# Altium schematic sheet 1400-10010-SCH-Legend-02-Protection V4.3.SchDoc("1400-10010-SCH-Legend-02-Protection V4.3")
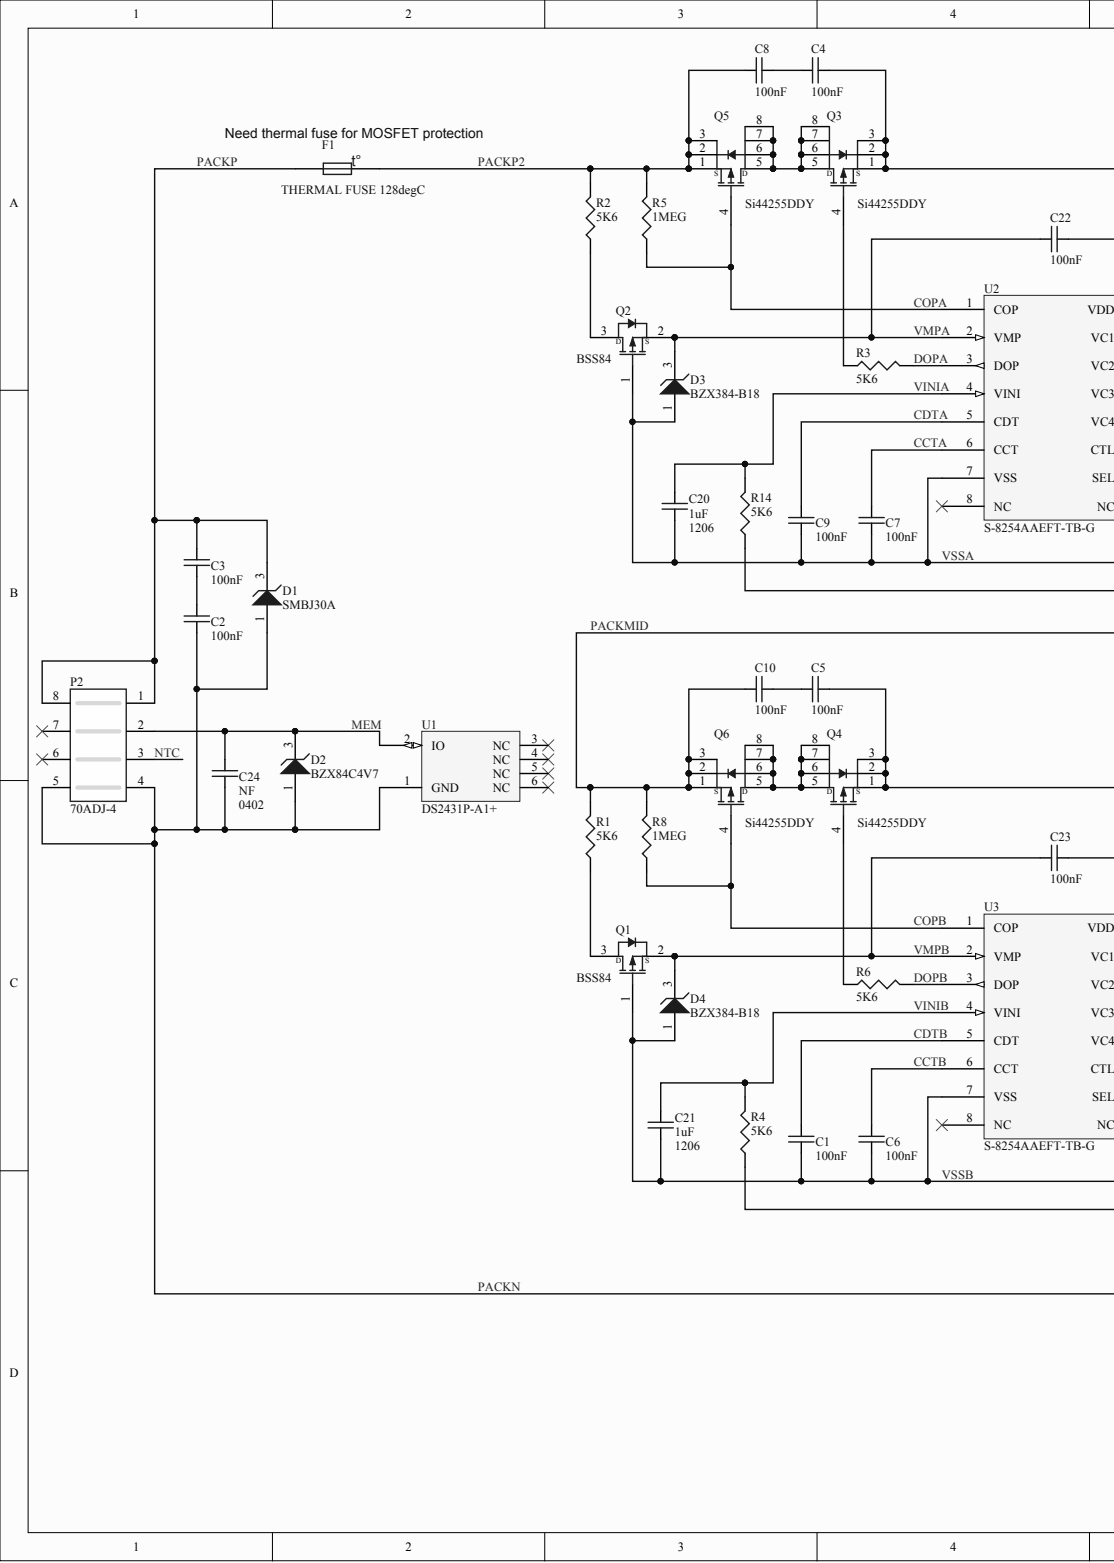
[diagram p0: 1400-10010-SCH-Legend-02-Protection V4.3.SchDoc, left]
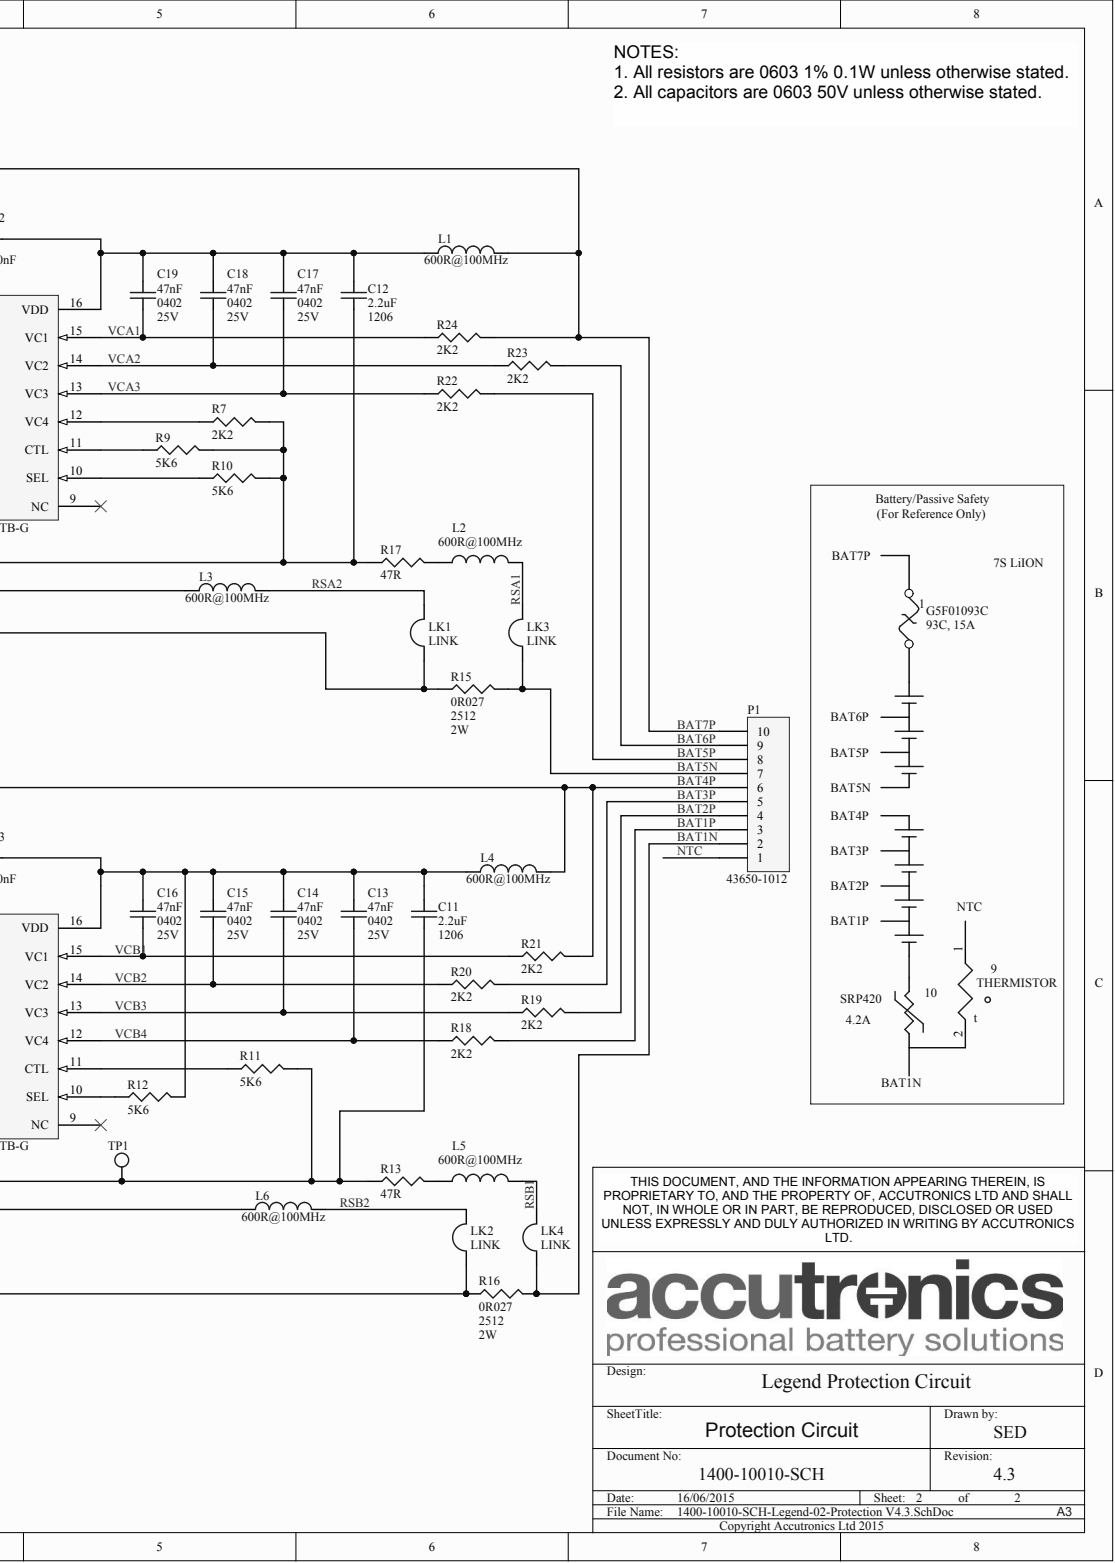
[diagram p1: 1400-10010-SCH-Legend-02-Protection V4.3.SchDoc, right]

## Parts
C1  100nF  [CAP0603]
C2  100nF  [CAP0603]
C3  100nF  [CAP0603]
C4  100nF  [CAP0603]
C5  100nF  [CAP0603]
C6  100nF  [CAP0603]
C7  100nF  [CAP0603]
C8  100nF  [CAP0603]
C9  100nF  [CAP0603]
C10  100nF  [CAP0603]
C11  2.2uF  SIZE: 1206  [CAP1206]
C12  2.2uF  SIZE: 1206  [CAP1206]
C13  47nF  SIZE: 0402  VOLTAGE: 25V  [CAP0402]
C14  47nF  SIZE: 0402  VOLTAGE: 25V  [CAP0402]
C15  47nF  SIZE: 0402  VOLTAGE: 25V  [CAP0402]
C16  47nF  SIZE: 0402  VOLTAGE: 25V  [CAP0402]
C17  47nF  SIZE: 0402  VOLTAGE: 25V  [CAP0402]
C18  47nF  SIZE: 0402  VOLTAGE: 25V  [CAP0402]
C19  47nF  SIZE: 0402  VOLTAGE: 25V  [CAP0402]
C20  1uF  SIZE: 1206  [CAP1206]
C21  1uF  SIZE: 1206  [CAP1206]
C22  100nF  [CAP0603]
C23  100nF  [CAP0603]
C24  NF  SIZE: 0402  [CAP0402]  (no BOM)
D1  SMBJ30A  [SMB]
D2  BZX84C4V7  [SOT23]
D3  BZX384-B18  [SOD323]
D4  BZX384-B18  [SOD323]
F1  THERMAL FUSE 128degC  TYPE: FUSE  [G5THERMFUSEVERT]
L1  TOLERANCE: 600R@100MHz  [RES0402]
L2  TOLERANCE: 600R@100MHz  [RES0402]
L3  TOLERANCE: 600R@100MHz  [RES0402]
L4  TOLERANCE: 600R@100MHz  [RES0402]
L5  TOLERANCE: 600R@100MHz  [RES0402]
L6  TOLERANCE: 600R@100MHz  [RES0402]
LK1  LINK  [COPPERLINK]
LK2  LINK  [COPPERLINK]
LK3  LINK  [COPPERLINK]
LK4  LINK  [COPPERLINK]
P1  43650-1012  [43650-10xx]
P2  70ADJ-4  [70ADJ-4-FL0]
Q1  BSS84  [SOT23]
Q2  BSS84  [SOT23]
Q3  Si44255DDY  [SOIC127P600-8N]
Q4  Si44255DDY  [SOIC127P600-8N]
Q5  Si44255DDY  [SOIC127P600-8N]
Q6  Si44255DDY  [SOIC127P600-8N]
R1  5K6  [RES0603]
R2  5K6  [RES0603]
R3  5K6  [RES0603]
R4  5K6  [RES0603]
R5  1MEG  [RES0603]
R6  5K6  [RES0603]
R7  2K2  [RES0603]
R8  1MEG  [RES0603]
R9  5K6  [RES0603]
R10  5K6  [RES0603]
R11  5K6  [RES0603]
R12  5K6  [RES0603]
R13  47R  [RES0603]
R14  5K6  [RES0603]
R15  0R027  POWER: 2W  SIZE: 2512  [RES2512]
R16  0R027  POWER: 2W  SIZE: 2512  [RES2512]
R17  47R  [RES0603]
R18  2K2  [RES0603]
R19  2K2  [RES0603]
R20  2K2  [RES0603]
R21  2K2  [RES0603]
R22  2K2  [RES0603]
R23  2K2  [RES0603]
R24  2K2  [RES0603]
TP1  Test Point  [TESTPOINT1MMRND]  (value not drawn)  (no BOM)
U1  DS2431P-A1+  [TSOC6]
U2  S-8254AAEFT-TB-G  [TSOP65P640X110-16N]
U3  S-8254AAEFT-TB-G  [TSOP65P640X110-16N]

## Nets
BAT1N: LK4.1 P1.2 R16.2
BAT1P: P1.3 R18.2
BAT2P: P1.4 R19.2
BAT3P: P1.5 R20.2
BAT4P: C5.2 L4.2 P1.6 Q4.1 Q4.2 Q4.3 R21.2
BAT5N: LK3.1 P1.7 R15.2
BAT5P: P1.8 R22.2
BAT6P: P1.9 R23.2
BAT7P: C4.2 L1.2 P1.10 Q3.1 Q3.2 Q3.3 R24.2
CCTA: C7.1 U2.6(CCT)
CCTB: C6.1 U3.6(CCT)
CDTA: C9.1 U2.5(CDT)
CDTB: C1.1 U3.5(CDT)
COPA: Q5.4 R5.1 U2.1(COP)
COPB: Q6.4 R8.1 U3.1(COP)
DOPA: R3.2 U2.3(DOP)
DOPB: R6.2 U3.3(DOP)
MEM: C24.1 D2.3 P2.2 U1.2(IO)
NTC: P1.1 P2.3
PACKMID: C10.1 LK1.1 Q6.1 Q6.2 Q6.3 R1.2 R8.2 R15.1
PACKN: C2.2 C24.2 D1.1 D2.1 LK2.1 P2.4 P2.5 R16.1 U1.1(GND)
PACKP: C3.1 D1.3 F1.1 P2.1 P2.8
PACKP2: C8.1 F1.2 Q5.1 Q5.2 Q5.3 R2.2 R5.2
RSA1: L2.2 LK3.2
RSA2: L3.2 LK1.2
RSB1: L5.2 LK4.2
RSB2: L6.2 LK2.2
VCA1: C19.2 R24.1 U2.15(VC1)
VCA2: C18.1 R23.1 U2.14(VC2)
VCA3: C17.2 R22.1 U2.13(VC3)
VCB1: C16.2 R21.1 U3.15(VC1)
VCB2: C15.2 R20.1 U3.14(VC2)
VCB3: C14.2 R19.1 U3.13(VC3)
VCB4: C13.2 R18.1 U3.12(VC4)
VINIA: C20.1 R14.2 U2.4(VINI)
VINIB: C21.1 R4.2 U3.4(VINI)
VMPA: C22.1 D3.3 Q2.2 U2.2(VMP)
VMPB: C23.1 D4.3 Q1.2 U3.2(VMP)
VSSA: C7.2 C9.2 C12.2 C20.2 D3.1 Q2.1 R7.2 R9.2 R10.2 R17.1 U2.7(VSS)
VSSB: C1.2 C6.2 C11.2 C21.2 D4.1 Q1.1 R11.2 R13.1 TP1.1 U3.7(VSS)
NetC2_1: C2.1 C3.2
NetC4_1: C4.1 C8.2
NetC5_1: C5.1 C10.2
NetC11_1: C11.1 C13.1 C14.1 C15.1 C16.1 C23.2 L4.1 R12.2 U3.16(VDD)
NetC12_1: C12.1 C17.1 C18.2 C19.1 C22.2 L1.1 U2.16(VDD)
NetL2_1: L2.1 R17.2
NetL3_1: L3.1 R14.1
NetL5_1: L5.1 R13.2
NetL6_1: L6.1 R4.1
NetQ1_3: Q1.3 R1.1
NetQ2_3: Q2.3 R2.1
NetQ3_4: Q3.4 R3.1
NetQ3_5: Q3.5 Q3.6 Q3.7 Q3.8 Q5.5 Q5.6 Q5.7 Q5.8
NetQ4_4: Q4.4 R6.1
NetQ4_5: Q4.5 Q4.6 Q4.7 Q4.8 Q6.5 Q6.6 Q6.7 Q6.8
NetR7_1: R7.1 U2.12(VC4)
NetR9_1: R9.1 U2.11(CTL)
NetR10_1: R10.1 U2.10(SEL)
NetR11_1: R11.1 U3.11(CTL)
NetR12_1: R12.1 U3.10(SEL)
N00049:

Not connected: P2 6 7; U1 3(NC) 4(NC) 5(NC) 6(NC); U2 8(NC) 9(NC); U3 8(NC) 9(NC)

## Library data of the parts
C1-C10 C22 C23 = CAPACITOR 0603  X7R 10% 50V 100nF: Non-polarised capacitor; Alt1Manufacturer: Murata; Alt1ManufacturerPN: GRM188R71H104KA93D; Alt2Manufacturer: TDK; Alt2ManufacturerPN: C1608X7R1H104KT; Manufacturer: AVX; ManufacturerPN: 06035C104KAZ2A; Accutronics Electronics PN: 1030-10037; Alt1Cost: 0.0152; Alt1Datasheet: ..\..\Datasheets\Capacitors\Murata\GRM188R71H104KA93.pdf; Alt1MOQ: 4000; Alt2Cost: 0.018; Alt2Datasheet: ..\..\Datasheets\Capacitors\TDK\C1608X7R1H104K.pdf; Cost ($): 0.113; Database Table Name: CAPACITORS; Field12: X7R; ID: 37; TOLERANCE: 10%; VOLTAGE: 50V; Alt1Approvals: -; Alt2Approvals: -; Alt2MOQ: 4000; Approvals: -; Component Kind: Standard; Datasheet Link: ..\..\Datasheets\Capacitors\AVX\cx7r.pdf; DESC: CAPACITOR 0603  X7R 10% 50V 100nF; MOQ: 4000; SIZE: 0603; TYPE: CAPACITOR; VALUE: 100nF; Library Name: PartDatabaseLibAccessDb.DbLib
C11 C12 = CAPACITOR 1206  X7R 10% 50V 2.2uF: Non-polarised capacitor; Alt1Manufacturer: Kemet; Alt1ManufacturerPN: C1206C225K5RAC; Manufacturer: Murata; ManufacturerPN: GRM31CR71H225KA88L; Accutronics Electronics PN: 1030-10030; Alt1Cost: 0.0898; Alt1Datasheet: ..\..\Datasheets\Capacitors\Kemet\F3102X7R.pdf; Alt1MOQ: 2000; Database Table Name: CAPACITORS; Field12: X7R; ID: 30; TOLERANCE: 10%; VOLTAGE: 50V; Alt1Approvals: -; Approvals: -; Component Kind: Standard; Cost ($): 0.0898; Datasheet Link: ..\..\Datasheets\Capacitors\Murata\GRM31CR71H225KA88.pdf; DESC: CAPACITOR 1206  X7R 10% 50V 2.2uF; MOQ: 2000; TYPE: CAPACITOR; VALUE: 2.2uF; Library Name: PartDatabaseLibAccessDb.DbLib
C13-C19 = CAPACITOR 0402  X7R 10% 25V 47nF: Non-polarised capacitor; Alt1Manufacturer: TDK; Alt1ManufacturerPN: C1005X7R1E473K; Alt2Manufacturer: Kemet; Alt2ManufacturerPN: C0402C473K3RAC; Manufacturer: Murata; ManufacturerPN: GRM155R71E473KA88D; Accutronics Electronics PN: 1030-10048; Alt1Cost: 0.0049; Alt1Datasheet: ..\..\Datasheets\Capacitors\TDK\General Multilayer Ceramic Chip Capacitors C series 0603 - e412_c.pdf; Alt1MOQ: 10000; Alt2Cost: 0.002; Alt2Datasheet: ..\..\Datasheets\Capacitors\Panasonic\ECG Type - Multilayer Ceramic Capacitors.pdf; Cost ($): 0.006; Database Table Name: CAPACITORS; Field12: X7R; ID: 48; TOLERANCE: 10%; Alt1Approvals: -; Alt2Approvals: -; Alt2MOQ: 10000; Approvals: -; Component Kind: Standard; Datasheet Link: ..\..\Datasheets\Capacitors\Murata\GRM155R71E473KA88 0402 25V 47nF.pdf; DESC: CAPACITOR 0402  X7R 10% 25V 47nF; MOQ: 10000; TYPE: CAPACITOR; VALUE: 47nF; Library Name: PartDatabaseLibAccessDb.DbLib
C20 C21 = CAPACITOR 1206  X7R 10% 50V 1uF: Non-polarised capacitor; Alt1Manufacturer: Kemet; Alt1ManufacturerPN: C1206F105K5RACTU; Alt2Manufacturer: AVX; Alt2ManufacturerPN: 12065C105KAT2A; Manufacturer: Murata; ManufacturerPN: GRM31CR71H105KA61L; Accutronics Electronics PN: 1030-10044; Alt1Cost: 0.15; Alt1Datasheet: ../../Datasheets/Capacitors/Kemet/F3102X7R.pdf; Alt1MOQ: 2000; Alt2Cost: 0.263; Alt2Datasheet: ../../Datasheets/Capacitors/AVX/cx7r.pdf; Cost ($): 0.074; Database Table Name: CAPACITORS; Field12: X7R; ID: 44; TOLERANCE: 10%; VOLTAGE: 50V; Alt1Approvals: -; Alt2Approvals: -; Alt2MOQ: 2000; Approvals: -; Component Kind: Standard; Datasheet Link: ..\..\Datasheets\Capacitors\Murata\Chip Monolithic Ceramic Capacitors - c02e.pdf; DESC: CAPACITOR 1206  X7R 10% 50V 1uF; MOQ: 3000; TYPE: CAPACITOR; VALUE: 1uF; Library Name: PartDatabaseLibAccessDb.DbLib
C24 = CAPACITOR 0402 X7R 10% 50V 2.2nF: Non-polarised capacitor; Alt1Manufacturer: TDK; Alt1ManufacturerPN: C1005X7R1H222K; Alt2Manufacturer: Kemet; Alt2ManufacturerPN: C0402C222K3RACTU; Manufacturer: Murata; ManufacturerPN: GRM155R71H222KA01D; Accutronics Electronics PN: 1031-10014; Alt1Cost: 0.0036; Alt1Datasheet: ..\..\Datasheets\Capacitors\TDK\General Multilayer Ceramic Chip Capacitors C series 0603 - e412_c.pdf; Alt1MOQ: 10000; Alt2Cost: 0.0119; Alt2Datasheet: ..\..\Datasheets\Capacitors\Panasonic\ECG Type - Multilayer Ceramic Capacitors.pdf; Cost ($): 0.003; Database Table Name: CAPACITORS 0402; Field11: X7R; ID: 14; TOLERANCE: 10%; VALUE: 2.2nF; VOLTAGE: 50V; Alt1Approvals: -; Alt2Approvals: -; Alt2MOQ: 10000; Approvals: -; Datasheet Link: ..\..\Datasheets\Capacitors\Murata\GRM155R71E473KA88 0402 25V 47nF.pdf; DESC: CAPACITOR 0402 X7R 10% 50V 2.2nF; MOQ: 10000; TYPE: CAPACITOR; Library Name: PartDatabaseLibAccessDb.DbLib
D1 = DIODE SMB TRANSIL 30V 600W SMBJ30A: Zener Voltage Regulator Diode; Alt1Manufacturer: On Semiconductor; Alt1ManufacturerPN: 1SMB30AT3G; Alt2Manufacturer: Vishay General Semiconductor; Alt2ManufacturerPN: SMBJ30A-E3; Manufacturer: ST Microelectronics; ManufacturerPN: SMBJ30A-TR; Accutronics Electronics PN: 1050-10026; Alt1Approvals: UL Recognised; Alt1Cost: 0.3066; Alt1Datasheet: ..\..\Datasheets\Diodes\OnSemi\1SMB5.0AT3-D Transil 600W.pdf; Alt1MOQ: 2500; Alt2Cost: 0.118; Alt2Datasheet: ..\..\Datasheets\Diodes\Vishay\SMBJ5.0 thru SMBJ188CA TransZorb.pdf; Alt2MOQ: 3200; Cost ($): 0.17; Current/Power: 600W; Database Table Name: DIODES; ID: 26; Voltage: 30V; Alt2Approvals: UL Recognised; Approvals: UL Recognised; Component Kind: Standard; Datasheet Link: ..\..\Datasheets\Diodes\ST\SMBJ Transil 600W.pdf; DESC: DIODE SMB TRANSIL 30V 600W SMBJ30A; FUNCTION: TRANSIL; MOQ: 2500; PACKAGE: SMB; ShortPN: SMBJ30A; TYPE: DIODE; Library Name: PartDatabaseLibAccessDb.DbLib
D2 = DIODE SOT23 ZENER  5% 4V7 225mW BZX84C4V7: Zener Voltage Regulator Diode; Alt1Manufacturer: Philips Semiconductor; Alt1ManufacturerPN: BZX84-C4V7 T/R; Alt2Manufacturer: On Semiconductor; Alt2ManufacturerPN: BZX84C4V7LT1G; Manufacturer: Fairchild Semiconductor; Accutronics Electronics PN: 1050-10014; Alt1Cost: 0.0407; Alt1Datasheet: ..\..\Datasheets\Diodes\Philips Semiconductor\BZX84_SERIES_3.pdf; Alt1MOQ: 3000; Alt2Approvals: Flammability Rating: UL94 V-0; Alt2Cost: 0.0533; Alt2Datasheet: ..\..\Datasheets\Diodes\OnSemi\BZX84C Zener Diodes.pdf; Cost ($): 0.0281; Current/Power: 225mW; Database Table Name: DIODES; ID: 14; Voltage: 4V7; Alt1Approvals: ?; Alt2MOQ: 3000; Approvals: ?; Component Kind: Standard; Datasheet Link: ..\..\Datasheets\Diodes\Fairchild Semiconductor\BZX84C5V6.pdf; DESC: DIODE SOT23 ZENER  5% 4V7 225mW BZX84C4V7; FUNCTION: ZENER  5%; ManufacturerPN: BZX84C4V7; MOQ: 3000; PACKAGE: SOT23; ShortPN: BZX84C4V7; TYPE: DIODE; Library Name: PartDatabaseLibAccessDb.DbLib
D3 D4 = DIODE SOD323 ZENER 2% 18V 0.2W BZX384-B18: Zener Voltage Regulator Diode; Alt1Manufacturer: Fairchild Semiconductor; Alt1ManufacturerPN: MM3Z18VB; Alt2Manufacturer: Rohm; Alt2ManufacturerPN: UDZSTE-1718B; Manufacturer: NXP Semiconductors; AccutronicsPN: 1050-10025; Alt1Cost: 0.027; Alt1Datasheet: ..\..\Datasheets\Diodes\Fairchild Semiconductor\MM3Z2V4B-MM3Z75VB Zener Diodes.pdf; Alt1MOQ: 3000; Alt2Cost: 0.083; Alt2Datasheet: ..\..\Datasheets\Diodes\Rohm\Zener SOD-323 udzs18b.pdf; Cost ($): 0.07; Current/Power: 0.2W; Database Table Name: DIODES; Voltage: 18V; Alt1Approvals: ?; Alt2Approvals: ?; Alt2MOQ: 3000; Approvals: ?; Component Kind: Standard; Datasheet Link: ..\..\Datasheets\Diodes\OnSemi\MBR120ESFT1 1A 20V Schottky Rectifier.pdf; DESC: DIODE SOD323 ZENER 2% 18V 0.2W BZX384-B18; FUNCTION: ZENER 2%; ManufacturerPN: BZX384-B18; MOQ: 3000; PACKAGE: SOD323; ShortPN: BZX384-B18; TYPE: DIODE; Library Name: PartDatabaseLibAccessDb.DbLib
F1 = FUSE TH G5 THERMAL 128degC: Thermal Fuse; Manufacturer: Thermodisc; ManufacturerPN: G5A101128C; Approvals: UL; Cost ($): 0.6; Database Table Name: MISC; MoltechPN: 1150-10011; Component Kind: Standard; Datasheet Link: ..\..\Datasheets\Misc\Thermal Fuse\Microtemp Thermal Cutoffs - PDF-TCOs.old.pdf; DESC: FUSE TH G5 THERMAL 128degC; FUNCTION: G5 THERMAL 128degC; MOQ: 1000; PACKAGE: TH; ShortPN: THERMAL FUSE 128degC; Library Name: PartDatabaseLibAccessDb.DbLib
L1-L6 = FERRITE 0402 600R@100MHz 1R 200mA: Inductor; Alt1Manufacturer: Murata; Alt1ManufacturerPN: BLM15AG601SN1; Manufacturer: Wurth Electronics Ltd; ManufacturerPN: 74279279; AccutronicsPN: 1130-10008; Alt1Cost: 0.0243; Alt1Datasheet: ..\..\Datasheets\Magnetics\Murata\Ferrites\BLM15A_SN Series 0402 Chip EMIFIL Inductor Type.pdf; Alt1MOQ: 10000; Cost ($): 0.0470; Database Table Name: MAGNETICS; DCR: 1R; MaxI: 200mA; Alt1Approvals: ?; Approvals: -; Component Kind: Standard; Datasheet Link: ..\..\Datasheets\Magnetics\Wurth Elektronik\74279279 0402 600ohm Ferrite.pdf; DESC: FERRITE 0402 600R@100MHz 1R 200mA; MOQ: 4000; Signal Integrity: Inductor; Simulation: INDUCTOR; SIZE: 0402; TYPE: FERRITE; Library Name: PartDatabaseLibAccessDb.DbLib
LK1-LK4 = COPPER  LINK: COPPER LINK; Accutronics Electronics PN: 1150-10070; Database Table Name: MISC; ID: 70; Component Kind: Net Tie; DESC: COPPER  LINK; FUNCTION: LINK; ShortPN: LINK; TYPE: COPPER; Library Name: PartDatabaseLibAccessDb.DbLib
P1 = CONNECTOR HEADER SMT 3MM 10WAY: Header, 10way; Manufacturer: Molex; Accutronics Electronics PN: 1090-10005; Cost ($): 5.54; Database Table Name: CONNECTORS; ID: 5; PITCH: 3MM; Approvals: -; Component Kind: Standard; Datasheet Link: ..\..\Datasheets\Connectors\Hirose\DF4 2mm Pitch Connector Directly Mounted on Board.pdf; DESC: CONNECTOR HEADER SMT 3MM 10WAY; Field8: HEADER; ManufacturerPN: 43650-1012; MOQ: 5000; MOUNTING: SMT; No WAYS: 10WAY; TYPE: CONNECTOR; Library Name: PartDatabaseLibAccessDb.DbLib
P2 = CONNECTOR CONTACT (STD) SMT 4MM 4WAY: 70ADJ-4 Battery Con; Alt1Manufacturer: Bourns; Alt1ManufacturerPN: 70ADJ-4-FL0G; Accutronics Electronics PN: 1090-10050; Alt1Approvals: UL94V-0; Alt1Cost: 1; Alt1Datasheet: ..\..\Datasheets\Connectors\Bourns\70ADx Battery Connector.pdf; Alt1MOQ: 500; Database Table Name: CONNECTORS; ID: 50; No WAYS: 4WAY; PITCH: 4MM; Approvals: UL94V-0; Component Kind: Standard; Cost ($): 1; Datasheet Link: ..\..\Datasheets\Connectors\Bourns\70ADx Battery Connector.pdf; DESC: CONNECTOR CONTACT (STD) SMT 4MM 4WAY; Field8: CONTACT (STD); Manufacturer: Bourns; ManufacturerPN: 70ADJ-4-FL0; MOQ: 250; MOUNTING: SMT; ShortPN: 70ADJ-4; Signal Integrity: Connector; TYPE: CONNECTOR; Library Name: PartDatabaseLibAccessDb.DbLib
Q1 Q2 = MOSFET SOT23 P-CHAN 130mA 50V BSS84: MOSFET-P-SINGLE-SOT23; Alt1Manufacturer: Zetex; Alt2Manufacturer: Philips Semiconductor; Alt2ManufacturerPN: BSS84 T/R; Manufacturer: On Semiconductor; ManufacturerPN: BSS84LT1G; Alt1Cost: 0.174; Alt1Datasheet: ..\..\Datasheets\Transistors\MOSFET\Zetex\BSS84 - P-channel enhancement mode vertical DMOS FET.pdf; Alt1MOQ: 3000; Alt2Cost: 0.0568; Alt2Datasheet: ..\..\Datasheets\Transistors\MOSFET\Philips\BSS84; P-channel enhancement mode vertical D-MOS transistor.pdf; Cost ($): 0.062; Database Table Name: TRANSISTORS; MoltechPN: 1110-10003; TYPE: MOSFET; Alt1Approvals: ?; Alt1ManufacturerPN: BSS84; Alt2Approvals: ?; Alt2MOQ: 3000; Approvals: ?; Component Kind: Standard; Datasheet Link: ..\..\Datasheets\Transistors\MOSFET\OnSemi\BSS84L Power MOSFET 50 V, 130 mA, P-Channel SOT-23.pdf; FUNCTION: P-CHAN 130mA 50V; MOQ: 3000; PACKAGE: SOT23; ShortPN: BSS84; Library Name: PartDatabaseLibAccessDb.DbLib
Q3-Q6 = MOSFET SO8 P-CHAN 13A 30V Si4425DDY: MOSFET-P-SINGLE-SO8; Manufacturer: Vishay Siliconix; ManufacturerPN: SI4425DDY-T1-GE3; Accutronics Electronics PN: 1110-10066; Approved: NO; Cost ($): 0.3; Database Table Name: TRANSISTORS; ID: 77; TranI: 12; TranV: 30; TYPE: MOSFET; Approvals: ?; Component Kind: Standard; DESC: MOSFET SO8 P-CHAN 13A 30V Si4425DDY; FUNCTION: P-CHAN 13A 30V; MOQ: 2500; PACKAGE: SO8; ShortPN: Si44255DDY; Library Name: PartDatabaseLibAccessDb.DbLib
R1-R3 R6 R9-R12 = RESISTOR 0603 1% 0.1W 5K6: Resistor; Manufacturer: ROHM; ManufacturerPN: MCR03EZPFX5601; Database Table Name: RESISTORS; MoltechPN: 1010-10046; POWER: 0.1W; TOLERANCE: 1%; Approvals: -; Component Kind: Standard; Cost: 0.0045; Datasheet Link: ..\..\Datasheets\Resistors\Rohm\mcr03.pdf; MOQ: 5000; SIZE: 0603; TYPE: RESISTOR; Value: 5K6; Library Name: PartDatabaseLibAccessDb.DbLib
R4 R14 = RESISTOR 0603 1% 0.1W 5K6: Alt1Manufacturer: TT-Welwyn; Alt1ManufacturerPN: WCR0603-5K6FI; Manufacturer: Yageo Corporation; ManufacturerPN: RC0603FR-075K6L; Alt1Cost: 0.01; Alt1Datasheet: ..\..\Datasheets\Resistors\Welwyn\WCR.pdf; Alt1MOQ: 5000; Database Table Name: RESISTORS; MoltechPN: 1010-10046; Alt1Approvals: -; Approvals: -; Component Kind: Standard; Cost ($): 0.01; Datasheet Link: ..\..\Datasheets\Resistors\Yageo\RC0603.pdf; DESC: RESISTOR 0603 1% 0.1W 5K6; Description: RESISTOR 0603 1% 0.1W 5K6; MOQ: 5000; POWER: 0.1W; SIZE: 0603; TOLERANCE: 1%; TYPE: RESISTOR; Value: 5K6; Library Name: PartDatabaseLibAccessDb.DbLib
R5 R8 = RESISTOR 0603 1% 0.1W 1MEG: Resistor; Manufacturer: ROHM; ManufacturerPN: MCR03EZPFX1004; Database Table Name: RESISTORS; MoltechPN: 1010-10073; POWER: 0.1W; TOLERANCE: 1%; Approvals: -; Component Kind: Standard; Cost: 0.0045; Datasheet Link: ..\..\Datasheets\Resistors\Rohm\mcr03.pdf; MOQ: 5000; SIZE: 0603; TYPE: RESISTOR; Value: 1MEG; Library Name: PartDatabaseLibAccessDb.DbLib
R7 R18-R24 = RESISTOR 0603 1% 0.1W 2K2: Resistor; Alt1Manufacturer: TT-Welwyn; Alt1ManufacturerPN: WCR0603-2K2FI; Manufacturer: Yageo Corporation; ManufacturerPN: RC0603FR-072K2L; Alt1Cost: 0.01; Alt1Datasheet: ..\..\Datasheets\Resistors\Welwyn\WCR.pdf; Alt1MOQ: 5000; Cost ($): 0.0048; Database Table Name: RESISTORS; MoltechPN: 1010-10041; POWER: 0.1W; TOLERANCE: 1%; Alt1Approvals: -; Approvals: -; Component Kind: Standard; Datasheet Link: ..\..\Datasheets\Resistors\Yageo\RC0603.pdf; DESC: RESISTOR 0603 1% 0.1W 2K2; MOQ: 5000; SIZE: 0603; TYPE: RESISTOR; Value: 2K2; Library Name: PartDatabaseLibAccessDb.DbLib
R13 R17 = RESISTOR 0603 1% 0.1W 47R: Resistor; Manufacturer: ROHM; ManufacturerPN: MCR03EZPFX47R0; Database Table Name: RESISTORS; MoltechPN: 1010-10021; POWER: 0.1W; TOLERANCE: 1%; Approvals: -; Component Kind: Standard; Cost: 0.0045; Datasheet Link: ..\..\Datasheets\Resistors\Rohm\mcr03.pdf; DESC: RESISTOR 0603 1% 0.1W 47R; MOQ: 5000; SIZE: 0603; TYPE: RESISTOR; Value: 47R; Library Name: PartDatabaseLibAccessDb.DbLib
R15 R16 = RESISTOR 2512 1% 2W 0R027: Resistor; Alt1Manufacturer: Vishay Dale; Alt1ManufacturerPN: WSL2512R0270FEA; Manufacturer: KOA; ManufacturerPN: TLRH3AWTTE27L0F; AccutronicsPN: 1011-10018; Alt1Cost: 0.57; Alt1Datasheet: ..\..\Datasheets\Resistors\Yageo\Yu-RL_51_PbFree_L_2.pdf; Alt1MOQ: 2000; Database Table Name: SENSE RESISTORS; TOLERANCE: 1%; Alt1Approvals: -; Approvals: -; Component Kind: Standard; Cost ($): 0.57; Datasheet Link: ..\..\Datasheets\Resistors\Yageo\Yu-RL_51_PbFree_L_2.pdf; DESC: RESISTOR 2512 1% 2W 0R027; MOQ: 2000; TYPE: RESISTOR; Value: 0R027; Library Name: PartDatabaseLibAccessDb.DbLib
TP1 = FOOTPRINT SMT TEST POINT 1mm ROUND: Test Point For PCB; Manufacturer: NoBOM; Cost ($): 0; Database Table Name: MISC; MoltechPN: 1150-10010; Approvals: ?; DESC: FOOTPRINT SMT TEST POINT 1mm ROUND; FUNCTION: TEST POINT 1mm ROUND; ManufacturerPN: NoBOM; MOQ: 0; PACKAGE: SMT; ShortPN: TESTPOINT1MMRND; TYPE: FOOTPRINT; Library Name: PartDatabaseLibAccessDb.DbLib
U1 = IC TSOC6 1024-Bit 1-Wire EEPROM DS2431P-A1+: Maxim/Dallas DS2431 1-wire 1024bit EEPROM; Alt1ManufacturerPN: DS2431P-A1+T; Accutronics Electronics PN: 1070-10159; Alt1Cost: 0.61; Alt1Datasheet: ..\..\Datasheets\IC\Maxim\DS2431 - 1024-Bit 1-Wire EEPROM.pdf; Alt1MOQ: 4000; Approved: NO; Database Table Name: IC; ID: 161; Alt1Approvals: -; Alt1Manufacturer: Maxim/Dallas; Approvals: -; Component Kind: Standard; Cost ($): 0.61; Datasheet Link: ..\..\Datasheets\IC\Maxim\DS2431 - 1024-Bit 1-Wire EEPROM.pdf; DESC: IC TSOC6 1024-Bit 1-Wire EEPROM DS2431P-A1+; FUNCTION: 1024-Bit 1-Wire EEPROM; Manufacturer: Maxim/Dallas; ManufacturerPN: DS2431P-A1+; MOQ: 1000; PACKAGE: TSOC6; ShortPN: DS2431P-A1+; TYPE: IC; Library Name: PartDatabaseLibAccessDb.DbLib
U2 U3 = IC TSSOP16 LI-ION 3S/4S PROTECTION S-8254AAEFT-TB-G: IC S-8254; Manufacturer: Seiko Instruments; Accutronics Electronics PN: 1070-10023; Approvals: ..\..\Datasheets\IC\Seiko\Battery Protection\S8254 Reliability Test Report - RT_S8254xxxFTTxG_E.pdf; Cost ($): 1.2; ID: 33; Component Kind: Standard; Database Table Name: IC; Datasheet Link: ..\..\Datasheets\IC\Seiko\Battery Protection\S8254 - Battery Protection IC for 3-Serial or 4-Serial-Cell Pack - S8254_E.pdf; DESC: IC TSSOP16 LI-ION 3S/4S PROTECTION S-8254AAEFT-TB-G; FUNCTION: LI-ION 3S/4S PROTECTION; ManufacturerPN: S-8254AAEFT-TB-G; MOQ: 2000; PACKAGE: TSSOP16; ShortPN: S-8254AAEFT-TB-G; TYPE: IC; Library Name: PartDatabaseLibAccessDb.DbLib
